# Altium schematic sheet Schematics.SchDoc
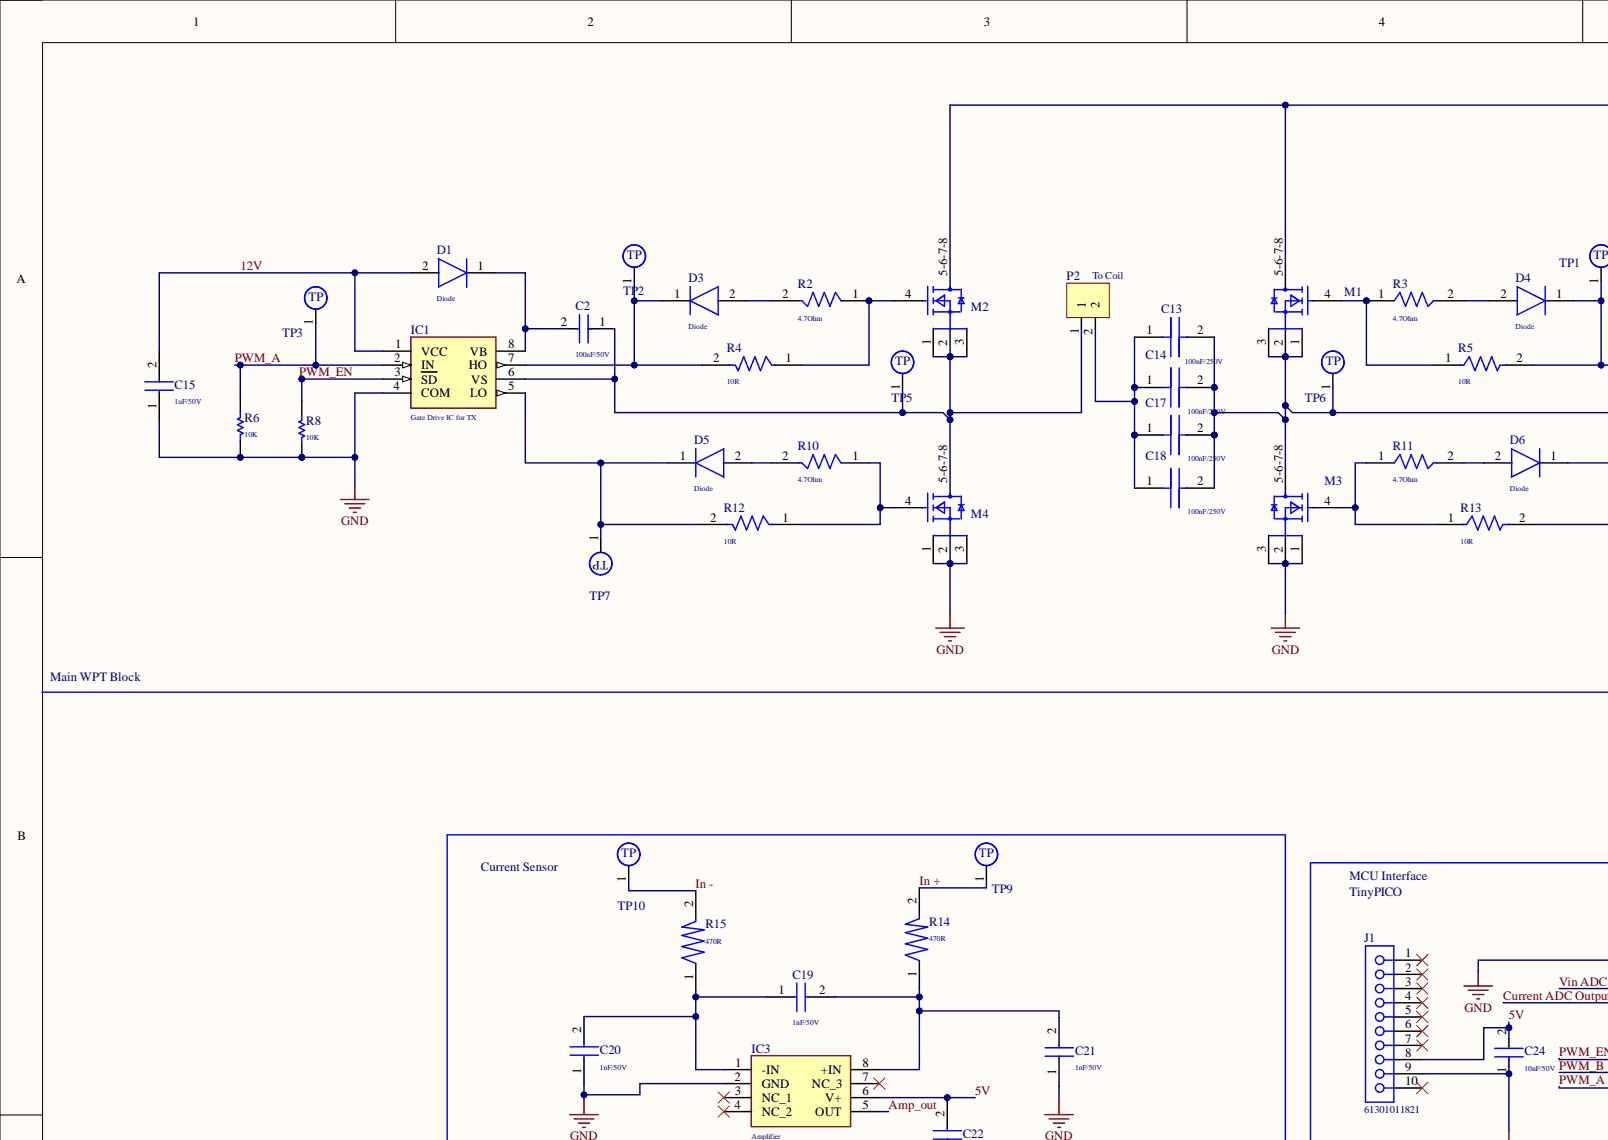
[diagram p0: Schematics.SchDoc, top left]
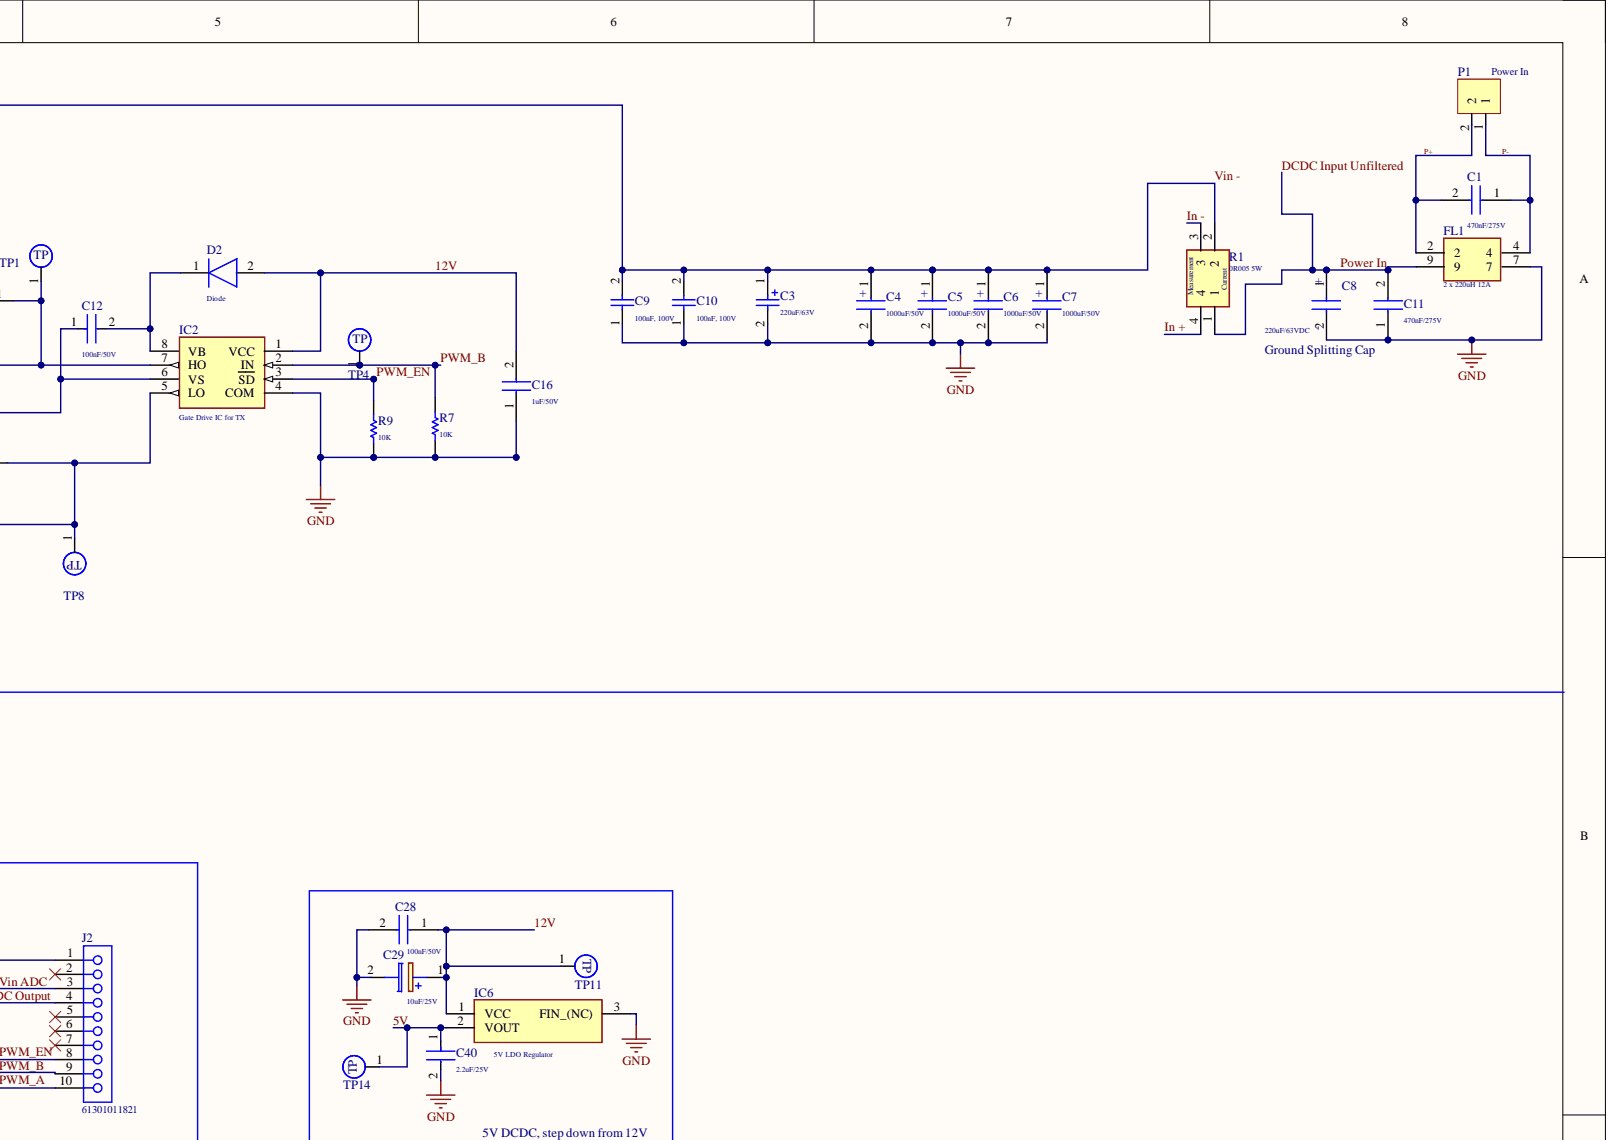
[diagram p1: Schematics.SchDoc, top right]
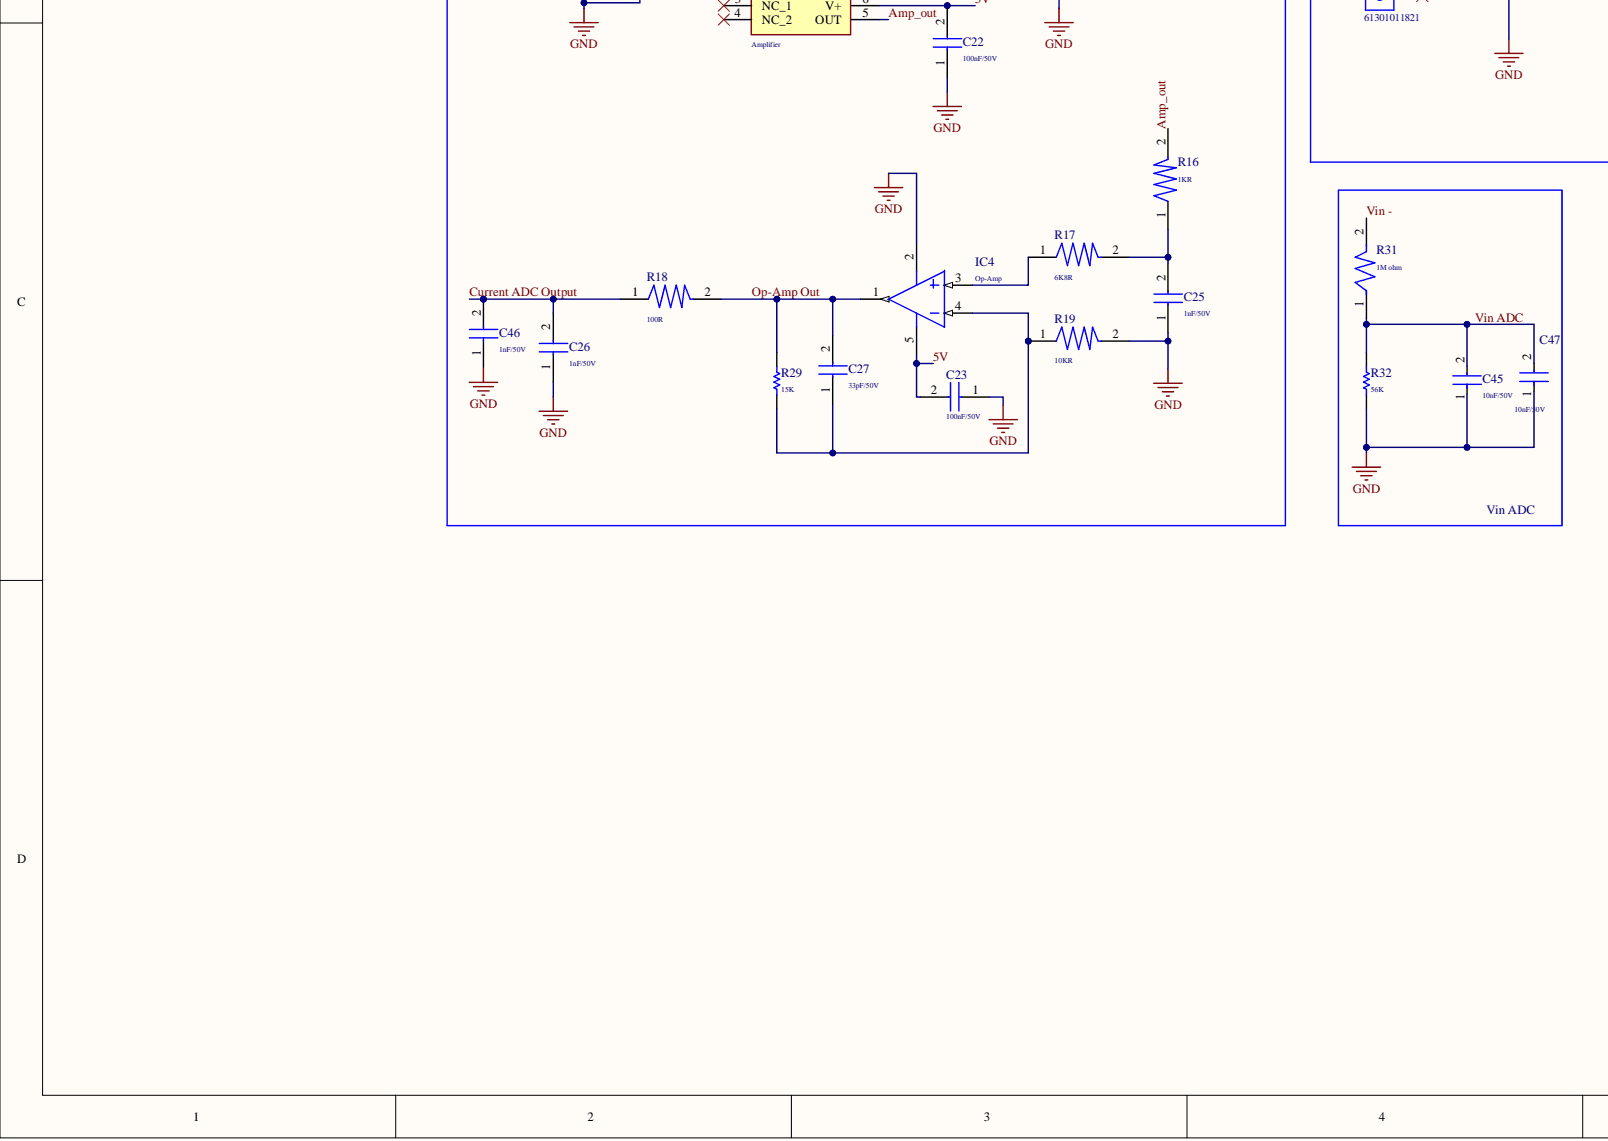
[diagram p2: Schematics.SchDoc, bottom left]
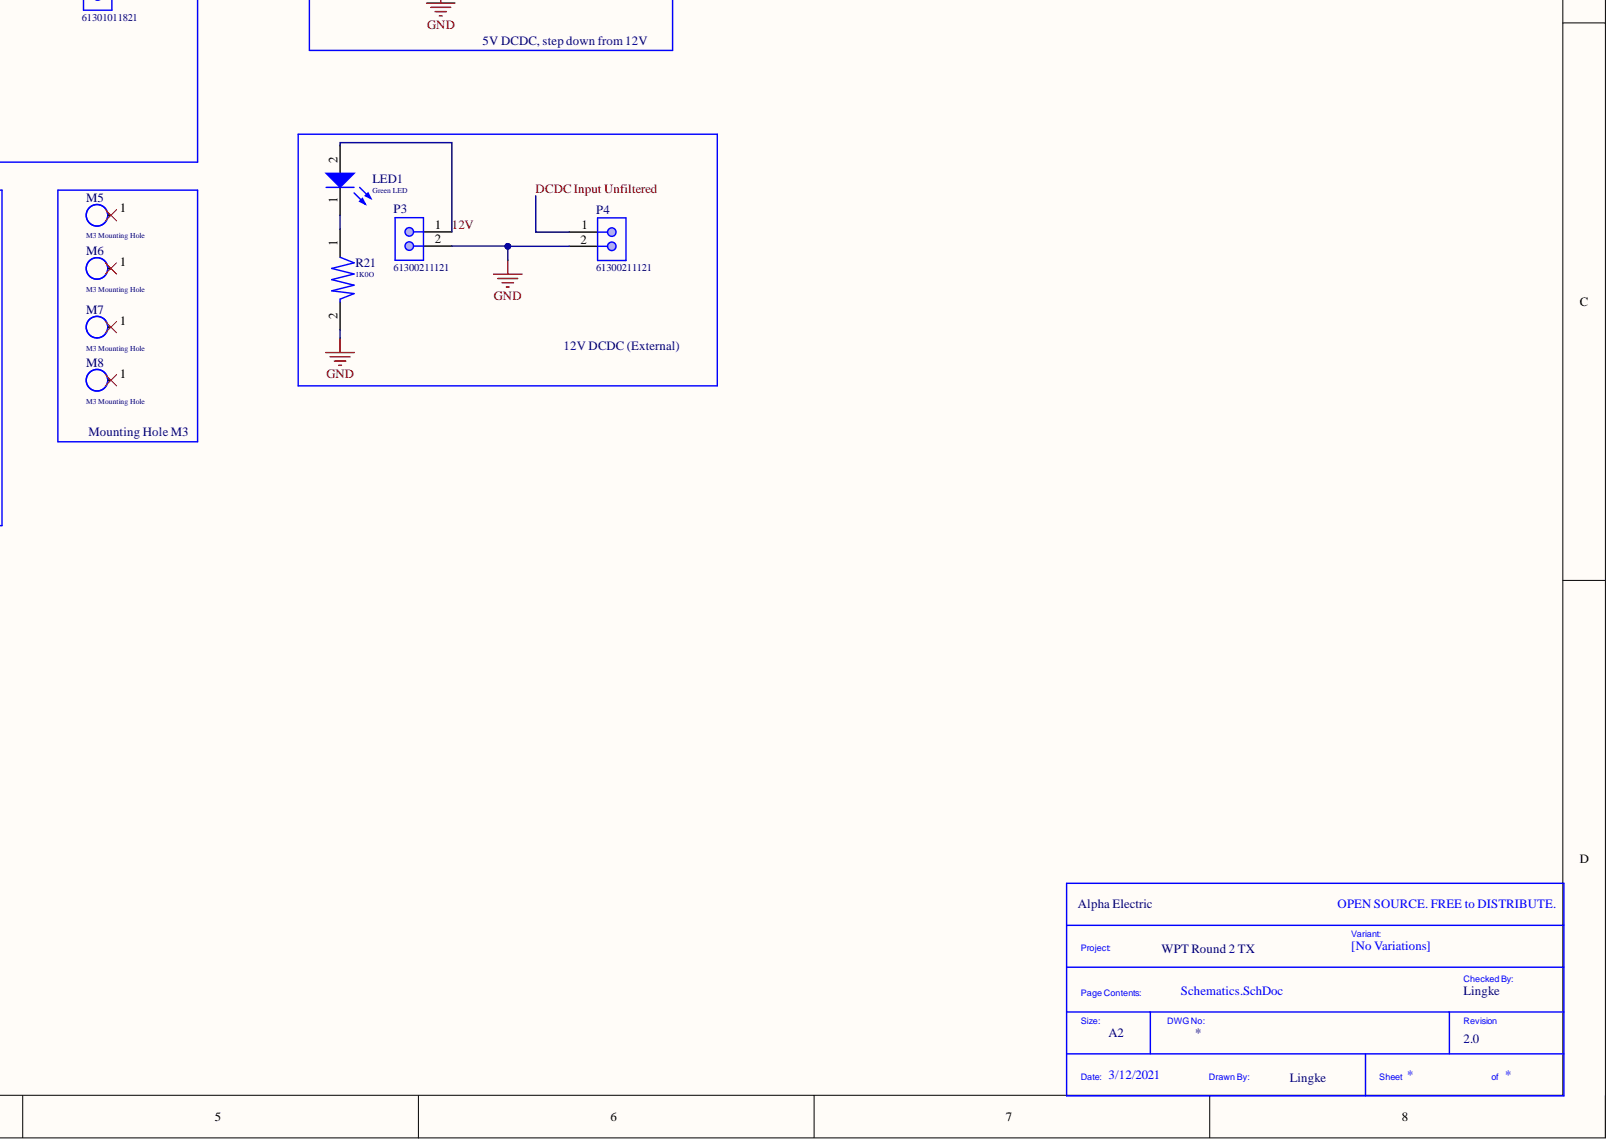
[diagram p3: Schematics.SchDoc, bottom right]

## Parts
*  Checked By: Lingke  Company: Alpha Electric  Project Name: WPT Round 2 TX  Revision: 2.0  Size: A2  (hidden comment: Title_Block)  (no BOM)
C1  470nF/275V  [890324025039CS]
C2  100nF/50V  [0805 Capacitor]
C3  220uF/63V  [WCAP-ATUL_D10H16]
C4  1000uF/50V  [CAPPRD500W62D1300H2650]
C5  1000uF/50V  [CAPPRD500W62D1300H2650]
C6  1000uF/50V  [CAPPRD500W62D1300H2650]
C7  1000uF/50V  [CAPPRD500W62D1300H2650]
C8  220uF/63VDC  [CAPPRD500W62D1000H2650]
C9  100nF, 100V  [WCAP-CSGP_0805_H=1.25mm]
C10  100nF, 100V  [WCAP-CSGP_0805_H=1.25mm]
C11  470nF/275V  [890324025039CS]
C12  100nF/50V  [0805 Capacitor]
C13  100nF/250V  [CAPC4532X220N]
C14  100nF/250V  [CAPC4532X220N]
C15  1uF/50V  [CAPC2012X135N]
C16  1uF/50V  [CAPC2012X135N]
C17  100nF/250V  [CAPC4532X220N]
C18  100nF/250V  [CAPC4532X220N]
C19  1nF/50V  [CAPC2012X90N]
C20  1nF/50V  [CAPC2012X90N]
C21  1nF/50V  [CAPC2012X90N]
C22  100nF/50V  [CAPC2012X90N]
C23  100nF/50V  [CAPC2012X90N]
C24  10nF/50V  [0805 Capacitor]
C25  1nF/50V  [CAPC2012X90N]
C26  1nF/50V  [CAPC2012X90N]
C27  33pF/50V  [CAPC2012X90N]
C28  100nF/50V  [CAPC2012X90N]
C29  10uF/25V  [CAPAE530X550N]
C40  2.2uF/25V  [0805 Capacitor]
C45  10nF/50V  [0805 Capacitor]
C46  1nF/50V  [CAPC2012X90N]
C47  10nF/50V  [0805 Capacitor]
D1  Diode  [SOD3716X135N]
D2  Diode  [SOD3716X135N]
D3  Diode  [SOD3716X135N]
D4  Diode  [SOD3716X135N]
D5  Diode  [SOD3716X135N]
D6  Diode  [SOD3716X135N]
FL1  2 x 220uH 12A  [744844221]
IC1  Gate Drive IC for TX  [SOIC127P600X175-8N]
IC2  Gate Drive IC for TX  [SOIC127P600X175-8N]
IC3  Amplifier  [SOIC127P600X175-8N]
IC4  Op-Amp  [ADI-RJ-5_N]
IC6  5V LDO Regulator  [ROHM_TO-252-3]
J1  61301011821  [61301011821]
J2  61301011821  [61301011821]
LED1  Green LED  Color: Green  [0805_A]
M1  Mosfet  [FP-PG-TDSON-8-MFG]  (value not drawn)
M2  Mosfet  [FP-PG-TDSON-8-MFG]  (value not drawn)
M3  Mosfet  [FP-PG-TDSON-8-MFG]  (value not drawn)
M4  Mosfet  [FP-PG-TDSON-8-MFG]  (value not drawn)
M5  M3 Mounting Hole  [M3 Hole]
M6  M3 Mounting Hole  [M3 Hole]
M7  M3 Mounting Hole  [M3 Hole]
M8  M3 Mounting Hole  [M3 Hole]
P1  Power In  [691404910002B]
P2  To Coil  [691404910002B]
P3  61300211121  [61300211121]
P4  61300211121  [61300211121]
R1  0R005 5W  [FC4L110R005FER]
R2  4.7Ohm  [FP-CRCW1206-e3-IPC_A]
R3  4.7Ohm  [FP-CRCW1206-e3-IPC_A]
R4  10R  [FP-CRCW1206-e3-IPC_A]
R5  10R  [FP-CRCW1206-e3-IPC_A]
R6  10K  [0805 Resistor]
R7  10K  [0805 Resistor]
R8  10K  [0805 Resistor]
R9  10K  [0805 Resistor]
R10  4.7Ohm  [FP-CRCW1206-e3-IPC_A]
R11  4.7Ohm  [FP-CRCW1206-e3-IPC_A]
R12  10R  [FP-CRCW1206-e3-IPC_A]
R13  10R  [FP-CRCW1206-e3-IPC_A]
R14  470R  [FP-CRCW0805-e3-MFG]
R15  470R  [FP-CRCW0805-e3-MFG]
R16  1KR  [FP-CRCW0805-e3-MFG]
R17  6K8R  [FP-CRCW0805-e3-MFG]
R18  100R  [FP-CRCW0805-e3-MFG]
R19  10KR  [FP-CRCW0805-e3-MFG]
R21  1K0O  [FP-CRCW0805-e3-MFG]
R29  15K  [0805 Resistor]
R31  1M ohm  [FP-CRCW0805-e3-MFG]
R32  56K  [0805 Resistor]
TP1  TP  [Test Point]  (no BOM)
TP2  TP  [Test Point]  (no BOM)
TP3  TP  [Test Point]  (no BOM)
TP4  TP  [Test Point]  (no BOM)
TP5  TP  [Test Point]  (no BOM)
TP6  TP  [Test Point]  (no BOM)
TP7  TP  [Test Point]  (no BOM)
TP8  TP  [Test Point]  (no BOM)
TP9  TP  [Test Point]  (no BOM)
TP10  TP  [Test Point]  (no BOM)
TP11  TP  [Test Point]  (no BOM)
TP14  TP  [Test Point]  (no BOM)

## Nets
GND: C3.2 C4.2 C5.2 C6.2 C7.2 C8.2 C9.1 C10.1 C11.1 C15.1 C16.1 C20.1 C21.1 C22.1 C23.1 C24.1 C25.1 C26.1 C28.2 C29.2 C40.2 C45.1 C46.1 C47.1 FL1.7 IC1.4(COM) IC2.4(COM) IC3.2(GND) IC4.2 IC6.3 J1.9 J2.1 M3.1 M3.2 M3.3 M4.1 M4.2 M4.3 P3.2 P4.2 R6.1 R7.1 R8.1 R9.1 R19.2 R21.2 R32.1
5V: C22.2 C23.2 C24.2 C40.1 IC3.6(V+) IC4.5 IC6.2 J1.8 TP14.1
12V: C15.2 C16.2 C28.1 C29.1 D1.2 D2.2 IC1.1(VCC) IC2.1(VCC) IC6.1 LED1.2 P3.1 TP11.1
Amp_out: IC3.5(OUT) R16.2
Current ADC Output: C26.2 C46.2 J2.4 R18.1
DCDC Input Unfiltered: C8.1 C11.2 FL1.9 P4.1 R1.1
In +: R1.4 R14.2 TP9.1
In -: R1.3 R15.2 TP10.1
Op-Amp Out: C27.2 IC4.1 R18.2 R29.2
P+: C1.2 FL1.2 P1.2
P-: C1.1 FL1.4 P1.1
PWM_A: IC1.2(IN) J2.10 R6.2 TP3.1
PWM_B: IC2.2(IN) J2.9 R7.2 TP4.1
PWM_EN: IC1.3(SD) IC2.3(SD) J2.8 R8.2 R9.2
Vin -: C3.1 C4.1 C5.1 C6.1 C7.1 C9.2 C10.2 M1.5-6-7-8 M2.5-6-7-8 R1.2 R31.2
Vin ADC: C45.2 C47.2 J2.3 R31.1 R32.2
NetC2_1: C2.1 IC1.6(VS) M2.1 M2.2 M2.3 M4.5-6-7-8 P2.1 TP5.1
NetC2_2: C2.2 D1.1 IC1.8(VB)
NetC12_1: C12.1 C13.2 C14.2 C17.2 C18.2 IC2.6(VS) M1.1 M1.2 M1.3 M3.5-6-7-8 TP6.1
NetC12_2: C12.2 D2.1 IC2.8(VB)
NetC13_1: C13.1 C14.1 C17.1 C18.1 P2.2
NetC19_1: C19.1 C20.2 IC3.1(-IN) R15.1
NetC19_2: C19.2 C21.2 IC3.8(+IN) R14.1
NetC25_2: C25.2 R16.1 R17.2
NetC27_1: C27.1 IC4.4 R19.1 R29.1
NetD3_1: D3.1 IC1.7(HO) R4.2 TP2.1
NetD3_2: D3.2 R2.2
NetD4_1: D4.1 IC2.7(HO) R5.2 TP1.1
NetD4_2: D4.2 R3.2
NetD5_1: D5.1 IC1.5(LO) R12.2 TP7.1
NetD5_2: D5.2 R10.2
NetD6_1: D6.1 IC2.5(LO) R13.2 TP8.1
NetD6_2: D6.2 R11.2
NetIC4_3: IC4.3 R17.1
NetLED1_1: LED1.1 R21.1
NetM1_4: M1.4 R3.1 R5.1
NetM2_4: M2.4 R2.1 R4.1
NetM3_4: M3.4 R11.1 R13.1
NetM4_4: M4.4 R10.1 R12.1

Not connected: IC3 3(NC_1) 4(NC_2) 7(NC_3); J1 1 2 3 4 5 6 7 10; J2 2 5 6 7; M5 1; M6 1; M7 1; M8 1

## Library data of the parts
* = Title_Block: Standard Title Block.; Library Name: Symbol Library.SchLib; Drawn By: Lingke
C1 C11 = 890324025039CS: Safety Capacitor, 470nF/275V; Manufacturer_Name: Wurth Elektronik; Component Kind: Standard; Height: 14.5mm; Library Name: Symbol Library.SchLib; Manufacturer_Part_Number: 890324025039CS
C2 C12 = 885012207098: Component Kind: Standard; Description: 100nF/50V; Library Name: Symbol Library.SchLib; Supplier 1: Mouser; Supplier Part Number 1: 710-885012207098
C3 = 860020775019: WCAP-ATUL Aluminum Electrolytic Capacitor, Radial, THT, D10xH16mm, 220uF/63V; Manufacturer: Wurth Elektronik; Manufacturer Part Number: 860040881014; Capacitance: 220uF; Capacitance Tolerance: +/-20%; Dissipation Factor: 9%; Endurance: 10000h; Leakage Current: 820uA; Rated Voltage: 63VDC; Ripple Current: 375mA; Technical Reference: ATDL410821M100DSPS1K000; Component Kind: Standard; ComponentLink1Description: Manufacturer; ComponentLink1URL: http://www.we-online.de; ComponentLink2Description: Datasheet; ComponentLink2URL: https://www.we-online.com/catalog/datasheet/860040881014.pdf; Diameter: 18mm; Height: 41mm; Library Name: Symbol Library.SchLib; Mounting Technology: THT; Operating Temperature: -40 to +105degC; Packaging: Ammo Pack; Published Date: October 2020; Supplier 1: Mouser; Supplier Part Number 1: 710-860020775019
C4-C7 = ESH108M050AL4AA: Capacitor 1000uF; Component Kind: Standard; Library Name: Symbol Library.SchLib; Supplier 1: Mouser; Supplier Part Number 1: 80-ESH108M050AL4AA
C8 = 860040775010: Polarised Cap, 220uF/63VDC; Manufacturer_Name: Wurth Elektronik; Component Kind: Standard; Datasheet Link: https://www.mouser.de/datasheet/2/445/860040775010-1725627.pdf; Height: 26.5mm; Library Name: Symbol Library.SchLib; Manufacturer_Part_Number: 860040775010
C9 C10 = 885012207128: WCAP-CSGP Multilayer Ceramic Chip Capacitor, General Purpose, size 0805, X7R, 100nF, 100V; Manufacturer: Wurth Elektronik; Capacitance Tolerance: +/-10%; Dissipation Factor: #NAME?; Isolation Resistance: >=1GOhm; Rated Voltage: 100VDC; Technical Reference: X7R0805104K100DFCT10000; Capacitance: 100nF; Ceramic Type: X7R; Component Kind: Standard; ComponentLink1Description: Manufacturer; ComponentLink1URL: http://www.we-online.de; ComponentLink2Description: Datasheet; ComponentLink2URL: https://www.we-online.com/catalog/datasheet/885012207128.pdf; Height: 1.25mm; Library Name: Symbol Library.SchLib; Manufacturer Part Number: 885012207128; Mounting Technology: SMT; Operating Temperature: -55 to +125degC; Packaging: Tape and Reel; Published Date: May 2020; Size: 0805
C13 C14 C17 C18 = C1812C104JAGACAUTO: Manufacturer_Name: Kemet; Component Kind: Standard; Datasheet Link: https://api.kemet.com/component-edge/download/datasheet/C1812C104JAGACAUTO.pdf; Description: 100nF/250V; Height: 2.2mm; Library Name: Symbol Library.SchLib; Manufacturer_Part_Number: C1812C104JAGACAUTO; Supplier 1: Mouser; Supplier Part Number 1: 80-C1812C104JAGAUTO
C15 C16 = 885012207103: Capacitor 1uF/50V; Manufacturer_Name: Wurth Elektronik; Component Kind: Standard; Datasheet Link: https://katalog.we-online.com/pbs/datasheet/885012207103.pdf; Height: 1.35mm; Library Name: Symbol Library.SchLib; Manufacturer_Part_Number: 885012207103; Supplier 1: Mouser; Supplier Part Number 1: 710-885012207103
C19-C21 C25 C26 C46 = 885012207086: Manufacturer_Name: Wurth Elektronik; Component Kind: Standard; Datasheet Link: https://katalog.we-online.com/pbs/datasheet/885012207086.pdf; Description: 1nF/50V; Height: 0.9mm; Library Name: Symbol Library.SchLib; Manufacturer_Part_Number: 885012207086; Supplier 1: Mouser; Supplier Part Number 1: 710-885012207086
C22 C23 C28 = 885012207098: Manufacturer_Name: Wurth Elektronik; Component Kind: Standard; Description: 100nF/50V; Height: 0.9mm; Library Name: Symbol Library.SchLib; Supplier 1: Mouser; Supplier Part Number 1: 710-885012207098
C24 C45 C47 = 885012207092: Component Kind: Standard; Description: 10nF/50V; Library Name: Symbol Library.SchLib; Supplier 1: Mouser; Supplier Part Number 1: 710-885012207092
C27 = C0805C330J5GACTU: Capacitor 33pF/50V; Manufacturer_Name: Kemet; Component Kind: Standard; Library Name: Symbol Library.SchLib; Manufacturer_Part_Number: C0805C330J5GACTU; Supplier 1: Mouser; Supplier Part Number 1: 80-C0805C330J5G
C29 = 865060440001: Capacitor Polarised 10uF/25V; Manufacturer_Name: Wurth Elektronik; Component Kind: Standard; Datasheet Link: http://katalog.we-online.com/pbs/datasheet/865060440001.pdf; Height: 5.5mm; Library Name: Symbol Library.SchLib; Manufacturer_Part_Number: 865060440001
C40 = 885012207079: Capacitance: 2.2; Dielectric: X5R; Voltage Rating (DC): 25V; Case Code (Imperial): 0805; Case Code (Metric): 2012; Case/Package: 0805; Component Kind: Standard; Description: 2.2uF/25V; Library Name: Symbol Library.SchLib; Max Operating Temperature: 125°C; Package Quantity: 2000; Supplier 1: Mouser; Supplier Part Number 1: 710-885012207079
D1-D6 = 1N4148W-E3-08: Manufacturer_Name: Vishay; Component Kind: Standard; Datasheet Link: https://componentsearchengine.com//1N4148W-E3-08.pdf; Description: Diode; Height: 1.35mm; Library Name: Symbol Library.SchLib; Manufacturer_Part_Number: 1N4148W-E3-08; Mouser2 Part Number: 78-1N4148W-E3-08; Mouser2 Price/Stock: https://www.mouser.com/Search/Refine.aspx?Keyword=78-1N4148W-E3-08; Supplier 1: Digi-Key; Supplier Part Number 1: 1N4148W-E3-08CT-ND
FL1 = 744844221: Common Mode Choke 220uH 12A; Manufacturer_Name: Wurth Elektronik; Component Kind: Standard; Height: 33mm; Library Name: Symbol Library.SchLib; Manufacturer_Part_Number: 744844221
IC1 IC2 = IRS2008SPBF: Gate Drive IC; Manufacturer_Name: Infineon; Component Kind: Standard; Height: 1.75mm; Library Name: Symbol Library.SchLib; Manufacturer_Part_Number: IRS2008SPBF; Supplier 1: Mouser; Supplier Part Number 1: 726-IRS2008SPBF
IC3 = AD8215YRZ: Integrated Circuit Amplifier; Manufacturer_Name: Analog Devices; Component Kind: Standard; Datasheet Link: http://www.analog.com/static/imported-files/data_sheets/AD8215.pdf; Height: 1.75mm; Library Name: Symbol Library.SchLib; Manufacturer_Part_Number: AD8215YRZ; Supplier 1: Mouser; Supplier Part Number 1: 584-AD8215YRZ
IC4 = AD8031ARTZ-REEL7: Single Channel RRIO Operational Amplifier, 80 MHz BW, 35 V/us SR, Industrial, 5-pin SOT23 (RJ-5), Reel; Generic Part Number: AD8031; Manufacturer: Analog Devices; Amplifiers Per Package: 1; Input Bias Current: 450nA; Input Offset Voltage: 1mV; Iq/Amp: 900uA; Slew Rate: 35V/us; Small Signal Bandwidth: 80MHz; V Span Max: 12V; V Span Min: 2.7V; Code_JEDEC: MO-178-AA; Component Kind: Standard; ComponentLink1Description: Manufacturer URL; ComponentLink1URL: http://www.analog.com/en/index.html; ComponentLink2Description: Datasheet; ComponentLink2URL: http://www.analog.com/static/imported-files/data_sheets/AD8031_8032.pdf; ComponentLink3Description: Package Specification; ComponentLink3URL: http://www.analog.com/static/imported-files/packages/PKG_PDF/SOT-23(RJ)/RJ_5.pdf; ComponentLink4Description: Reference Circuit; ComponentLink4URL: http://www.analog.com/en/all-operational-amplifiers-op-amps/operational-amplifiers-op-amps/ad8031/products/product.html#product-recommendations; DatasheetVersion: Rev. D; Library Name: Symbol Library.SchLib; Mounting Technology: Surface Mount; PackageDescription: 5-Pin Small Outline Transistor Package, Body 2.9 x 1.6 mm, Pitch 0.95 mm; PackageReference: RJ-5; PackageVersion: 121608-A; Packing: Reel; PartNumber: AD8031ARTZ-REEL7; RevisionNote: Reference Circuit URL fixed; Supplier 1: Mouser; Supplier Part Number 1: 584-AD8031ARTZ-R7
IC6 = BD450M5FP-CE2: Manufacturer_Name: ROHM Semiconductor; Component Kind: Standard; Datasheet Link: https://componentsearchengine.com//BD450M5FP-CE2.pdf; Description: 5V LDO Regulator; Library Name: Symbol Library.SchLib; Manufacturer_Part_Number: BD450M5FP-CE2; Mouser2 Part Number: 755-BD450M5FP-CE2; Mouser2 Price/Stock: https://www.mouser.com/Search/Refine.aspx?Keyword=755-BD450M5FP-CE2
J1 J2 = 61301011821: WR-PHD Socket Header, THT, pitch 2.54mm, Single Row, Vertical, 10p; Manufacturer: Wurth Elektronik; Contact Resistance: 20mOhm; Pin Number: 10; Rated Current: 3A; Withstanding Voltage: 500VAC; Working Voltage: 250VAC; Component Kind: Standard; ComponentLink1Description: Manufacturer; ComponentLink1URL: http://www.we-online.de; ComponentLink2Description: Datasheet; ComponentLink2URL: https://www.we-online.com/catalog/datasheet/61301011821.pdf; Gender: Socket Header; Library Name: Altium_WR-PHD (rev20a).IntLib; Manufacturer Part Number: 61301011821; Mounting Technology: THT; Operating Temperature: -40 to +125degC; Orientation: Vertical; Packaging: Tray; Pitch: 2.54mm; Published Date: May 2020; Row Number: Single
LED1 = 150080GS75000: SMD mono-color Chip LED, WL-SMCW, Green; Manufacturer: Wuerth Elektronik; Component Kind: Standard; ComponentLink1Description: Manufacturer URL; ComponentLink1URL: http://www.we-online.com; ComponentLink2Description: Datasheet; ComponentLink2URL: http://katalog.we-online.com/led/datasheet/150080GS75000.pdf; Library Name: Symbol Library.SchLib; PackageReference: 0805_A; PartNumber: 150080GS75000; Supplier 1: Mouser; Supplier Part Number 1: 710-150080GS75000
M1-M4 = BSC040N10NS5ATMA1: MOSFET N-CH 100V 100A 8TDSON  Mosfet Switcher; Manufacturer: Infineon; Depletion Mode: False; Drain To Source Resistance: 3.4mΩ; Gate Charge At Vgs: 58nC @ 10V; Gate To Source Voltage: 20V; Input Capacitace At Vds: 4100pF @ 50V; Max Forward Diode Voltage: 1.1V; Max Junction Temp: +150°C; Number Of Channels: 1; Power Dissipation: 139W; Pulse Drain Current: 400A; Reverse Recovery Charge: 90nC; Reverse Recovery Time: 54ns; Rthja Max: 50K/W; Threshold Vgs Max: 3.8V; Threshold Vgs Min: 2.2V; Transconductance: 120S; Turn Off Delay Time: 32ns; Turn On Delay Time: 13ns; Typ Forward Diode Voltage: 0.9V; Automotive: No; Category: Trans; Component Kind: Standard; ComponentLink2Description: Datasheet; ComponentLink2URL: https://www.infineon.com/dgdl/Infineon-BSC040N10NS5-DS-v02_02-EN.pdf?fileId=5546d4624a0bf290014a0fbcee2e6b38; Continuous Drain Current: 100A; Device Class L1: Discrete Semiconductors; Device Class L2: Transistors; Device Class L3: MOSFETs; Digikey Description: MOSFET N-CH 100V 100A 8TDSON; Drain To Source Breakdown Voltage: 100V; Drain To Source Voltage: 100V; Footprint Url: https://www.infineon.com/dgdl/Infineon-PG-TDSON-8-7-FP-v01_00-EN.pdf?fileId=5546d46259d9a4bf015a1b6adadd1f0a; Height: 1.1mm; Lead Free: Yes; Library Name: Symbol Library.SchLib; Manufacturer Part Number: BSC040N10NS5ATMA1; Mouser Description: MOSFET N-Ch 100V 100A TDSON-8; Number Of N Channels: 1; Octopart: https://octopart.com/bsc040n10ns5atma1-infineon-52825711; Package: PG-TDSON-8; RoHS: TRUE; Supplier 1: Mouser; Supplier Part Number 1: 726-BSC040N10NS5ATMA; Temperature: -55°C to +150°C; Temperature Range High: +150°C; Temperature Range Low: -55°C
M5-M8 = M3_Mounting_hole: Component Kind: Standard; Library Name: Symbol Library.SchLib
P1 P2 = 691404910002B: Serie 4049 B - 7.50mm Screwless Clamp Power Connector WR-TBL, 2 pin; Manufacturer: Wurth Elektronik; Pin Number: 2; Component Kind: Standard; Datasheet URL: http://katalog.we-online.de/em/datasheet/6914049100xxB.pdf; Library Name: Symbol Library.SchLib; Manufacturer URL: http://www.we-online.de; Mounting Technology: THT; Operating Temperature: -40to105degC; Package Reference: 691404910002B; Packaging: Box; Part Number: 691404910002B; Pitch: 7.50mm; Supplier 1: Mouser; Supplier Part Number 1: 710-691404910002B; Publisher: WurthElektronik
P3 P4 = 61300211121: WR-PHD Pin Header, THT, pitch 2.54mm, Single Row, Vertical, 2p; Manufacturer: Wurth Elektronik; Contact Resistance: 20mOhm; Pin Number: 2; Rated Current: 3A; Withstanding Voltage: 500VAC; Working Voltage: 250VAC; Component Kind: Standard; ComponentLink1Description: Manufacturer; ComponentLink1URL: http://www.we-online.de; ComponentLink2Description: Datasheet; ComponentLink2URL: https://www.we-online.com/catalog/datasheet/61300211121.pdf; Gender: Pin Header; Library Name: Altium_WR-PHD (rev20a).IntLib; Manufacturer Part Number: 61300211121; Mounting Technology: THT; Operating Temperature: -40 to +105degC; Orientation: Vertical; Packaging: Bag; Pitch: 2.54mm; Published Date: May 2020; Row Number: Single
R1 = FC4L110R005FER: Resistor 0R005 5W; Manufacturer_Name: Ohmite; Component Kind: Standard; Height: 0.7mm; Library Name: Symbol Library.SchLib; Manufacturer_Part_Number: FC4L110R005FER; Supplier 1: Mouser; Supplier Part Number 1: 588-FC4L110R005FER
R2 R3 R10 R11 = CRCW12064R70FKEA: RES Thick Film, 4.7Ω, 1%, 0.25W, 100ppm/°C, 1206  MOSFET Gate Fast-off Resistor 4.7Ohm; Max Power Dissipation: 250mW; Resistance: 4.7R; Voltage Rating: 200V; Case Code (Imperial): 1206; Case Code (Metric): 3216; Case/Package: 1206; Component Kind: Standard; Composition: Thick Film; Contact Plating: Tin; Depth: 1.6mm; Features: Automotive AEC-Q200; Height: 0.024inch; Length: 3.2mm; Library Name: Symbol Library.SchLib; Max Operating Temperature: 155°C; Military Standard: Not; Min Operating Temperature: -55°C; Mount: Surface Mount; Number of Pins: 2; Number of Terminations: 2; Package Quantity: 10; Packaging: Tape and Reel; Power Rating: 250mW; Radiation Hardening: No; Supplier 1: Mouser; Supplier Part Number 1: 71-CRCW12064R70FKEA; Temperature Coefficient: 100ppm/°C; Tolerance: 1%; Weight: 0.000353oz; Width: 0.063inch
R4 R5 R12 R13 = CRCW120610R0FKEA: RES Thick Film, 10Ω, 1%, 0.25W, 100ppm/°C, 1206  MOSFET Gate Resistor 10Ohm; Max Power Dissipation: 250mW; Voltage Rating: 200V; Case Code (Imperial): 1206; Case Code (Metric): 3216; Case/Package: 1206; Component Kind: Standard; Composition: Thick Film; Contact Plating: Tin; Depth: 1.6mm; Features: Automotive AEC-Q200; Height: 0.55mm; Lead Free: Lead Free; Length: 3.2mm; Library Name: Symbol Library.SchLib; Max Operating Temperature: 155°C; Military Standard: Not; Min Operating Temperature: -55°C; Number of Pins: 2; Number of Terminations: 2; Package Quantity: 10; Packaging: Tape and Reel; Power Rating: 250mW; Radiation Hardening: No; Resistance: 10R; Supplier 1: Digi-Key; Supplier Part Number 1: 541-10.0FDKR-ND; Temperature Coefficient: 100ppm/°C; Termination: SMD/SMT; Tolerance: 1%; Voltage Rating (DC): 200V; Weight: 0.000353oz; Width: 0.063inch
R6-R9 = CRCW080510K0FKEB: High Presicion 10K Ohm; Component Kind: Standard; Library Name: Symbol Library.SchLib; Supplier 1: Newark; Supplier Part Number 1: 26R4046
R14 R15 = CRCW0805470RFKEB: Resistor 470 Ohm; Component Kind: Standard; Library Name: Symbol Library.SchLib; Number of Terminations: 2; Supplier 1: Mouser; Supplier Part Number 1: 71-CRCW0805470RFKEB
R16 = CRCW08051K00FKEA: Resistor 1k Ohm; Component Kind: Standard; Library Name: Symbol Library.SchLib; Number of Terminations: 2; Supplier 1: Mouser; Supplier Part Number 1: 71-CRCW0805-1.0K-E3
R17 = CRCW08056K80FKEA: Resistor 6.8K Ohm; Component Kind: Standard; Library Name: Symbol Library.SchLib; Number of Terminations: 2; Supplier 1: Mouser; Supplier Part Number 1: 71-CRCW0805-6.8K-E3
R18 = CRCW0805100RFKEB: Resistor 100 Ohm; Component Kind: Standard; Library Name: Symbol Library.SchLib; Number of Terminations: 2; Supplier 1: Mouser; Supplier Part Number 1: 71-CRCW0805100RFKEB
R19 = CRCW080510K0FKEA: Resistor 10K Ohm; Component Kind: Standard; Library Name: Symbol Library.SchLib; Number of Terminations: 2; Supplier 1: Mouser; Supplier Part Number 1: 71-CRCW0805-10K-E3
R21 = CRCW08051K00FKEAC: Resistor 1K0 Ohm; Component Kind: Standard; Library Name: Symbol Library.SchLib; Number of Terminations: 2; Supplier 1: Mouser; Supplier Part Number 1: 71-CRCW0805-1.0K-E3
R29 = ERA-6AED153V: 15K Ohm Resistor; Component Kind: Standard; Library Name: Symbol Library.SchLib; Supplier 1: Mouser; Supplier Part Number 1: 667-ERA-6AED153V
R31 = CRCW08051M00FKEA: RES Thick Film, 1MΩ, 1%, 0.125W, 100ppm/°C, 0805  1M Ohm Resistor; Power Rating: 125mW; Resistance: 1MR; Voltage Rating: 150V; Case Code (Imperial): 0805; Case Code (Metric): 2012; Case/Package: 0805; Component Kind: Standard; Composition: Thick Film; Contact Plating: Tin; Depth: 1.25mm; Height: 0.45mm; Lead Free: Lead Free; Length: 2mm; Library Name: Symbol Library.SchLib; Max Operating Temperature: 155°C; Military Standard: Not; Min Operating Temperature: -55°C; Mount: Surface Mount; Number of Pins: 2; Package Quantity: 5000; Packaging: Tape and Reel; Radiation Hardening: No; Supplier 1: Mouser; Supplier Part Number 1: 71-CRCW08051M00FKEAH; Temperature Coefficient: 100ppm/°C; Termination: SMD/SMT; Tolerance: 1%; Voltage Rating (DC): 150V; Weight: 0.000194oz; Width: 1.25mm
R32 = ERJ-6ENF5622V: 56K Ohm Resistor; Component Kind: Standard; Library Name: Symbol Library.SchLib; Supplier 1: Mouser; Supplier Part Number 1: 667-ERJ-6ENF5622V
TP1-TP11 TP14 = Test Point: Test Point 1mm by 1mm; Library Name: Symbol Library.SchLib
